# Altium schematic sheet schematic.SchDoc(schematic)
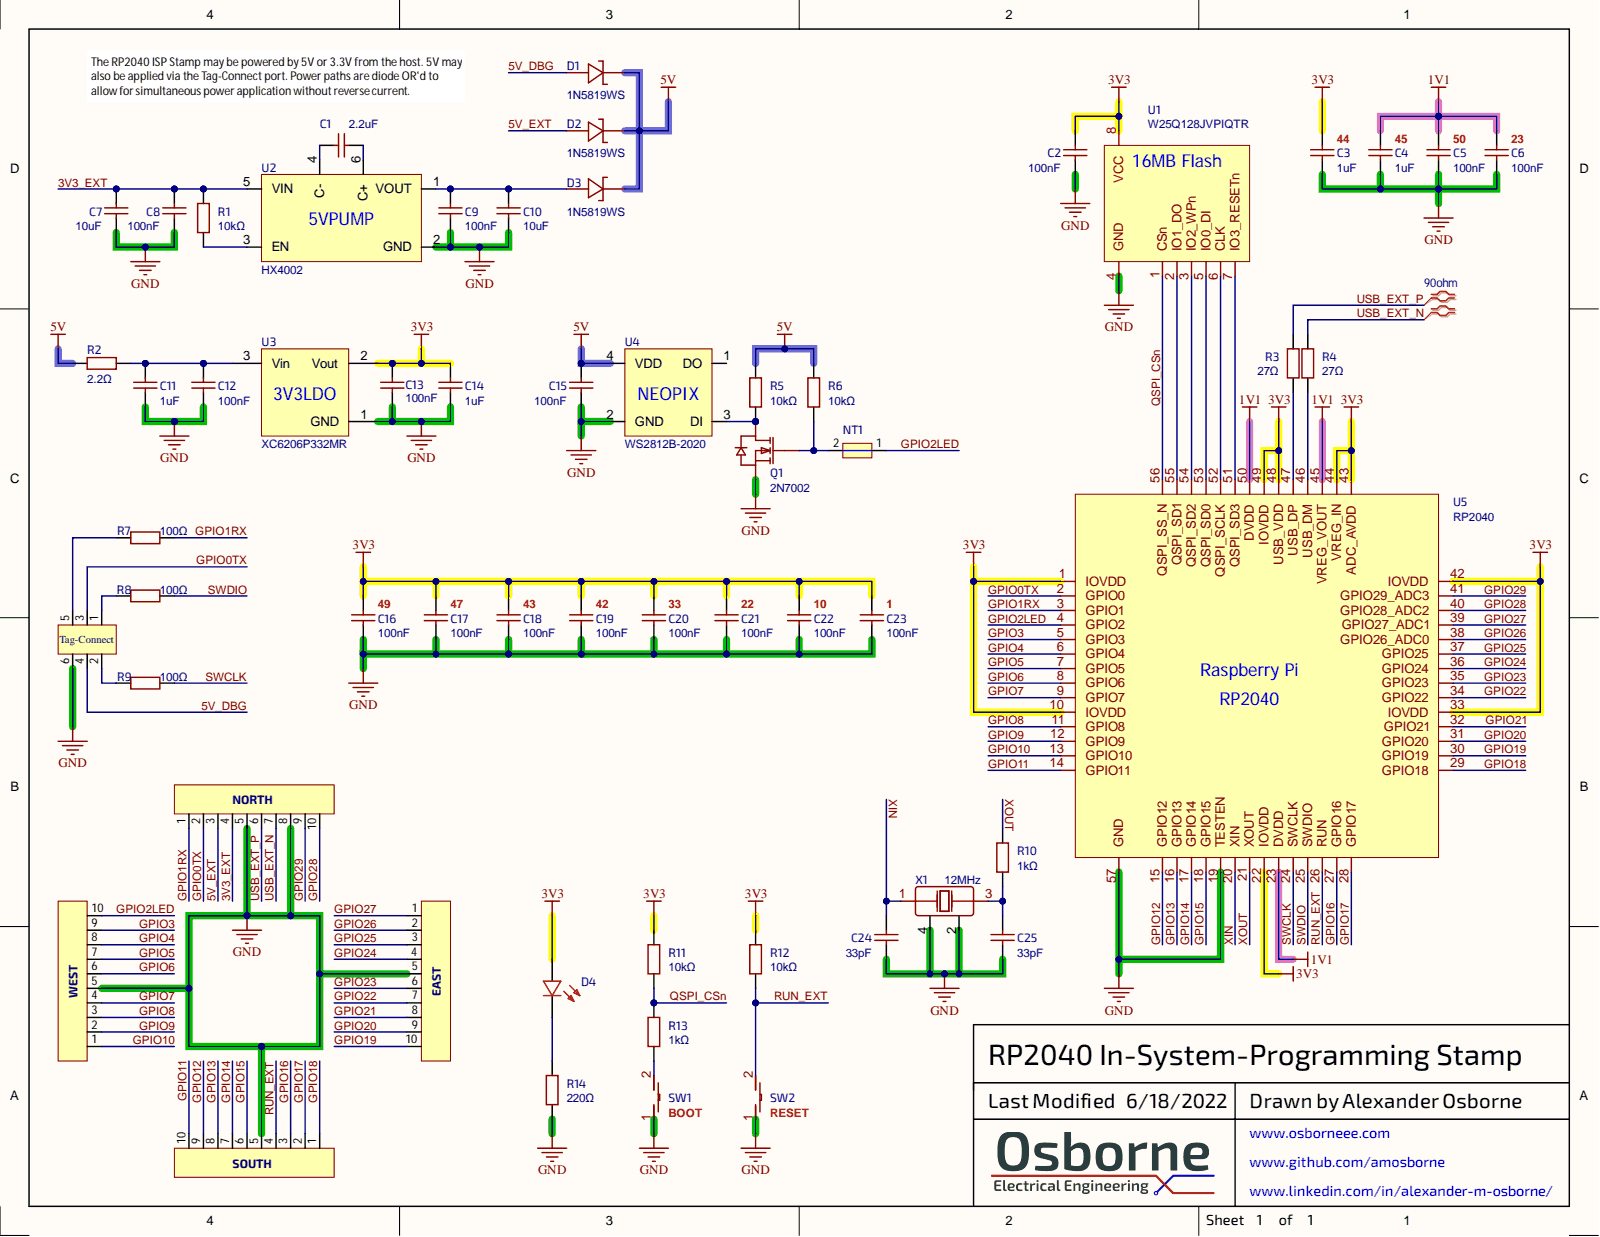
[diagram p0: schematic.SchDoc]

## Parts
C1  2.2uF  [C0603]
C2  100nF  [C0402_NEW]
C3  1uF  [C0402]
C4  1uF  [C0402]
C5  100nF  [C0402_NEW]
C6  100nF  [C0402_NEW]
C7  10uF  [C0603]
C8  100nF  [C0402_NEW]
C9  100nF  [C0402_NEW]
C10  10uF  [C0603]
C11  1uF  [C0402]
C12  100nF  [C0402_NEW]
C13  100nF  [C0402_NEW]
C14  1uF  [C0402]
C15  100nF  [C0402_NEW]
C16  100nF  [C0402_NEW]
C17  100nF  [C0402_NEW]
C18  100nF  [C0402_NEW]
C19  100nF  [C0402_NEW]
C20  100nF  [C0402_NEW]
C21  100nF  [C0402_NEW]
C22  100nF  [C0402_NEW]
C23  100nF  [C0402_NEW]
C24  33pF  [C0402]
C25  33pF  [C0402]
D1  1N5819WS  [SOD-323_L1.8-W1.3-LS2.5-RD]
D2  1N5819WS  [SOD-323_L1.8-W1.3-LS2.5-RD]
D3  1N5819WS  [SOD-323_L1.8-W1.3-LS2.5-RD]
D4  19-217/GHC-YR1S2/3T  [LED0603-R-RD]  (value not drawn)
EAST  STAMPEDGE  (value not drawn)  (no BOM)
J1  TC2030-IDC-NL  (value not drawn)  (no BOM)
NORTH  STAMPEDGE  (value not drawn)  (no BOM)
NT1  NETTIE  (value not drawn)
Q1  2N7002  [SOT-23-3_L2.9-W1.3-P1.90-LS2.4-BR]
R1  10kΩ  [R0402]
R2  2.2Ω  [R0603]
R3  27Ω  [R0603]
R4  27Ω  [R0603]
R5  10kΩ  [R0402]
R6  10kΩ  [R0402]
R7  100Ω  [R0402]
R8  100Ω  [R0402]
R9  100Ω  [R0402]
R10  1kΩ  [R0402]
R11  10kΩ  [R0402]
R12  10kΩ  [R0402]
R13  1kΩ  [R0402]
R14  220Ω  [R0402]
SOUTH  STAMPEDGE  (value not drawn)  (no BOM)
SW1  B3U-1000P  [KEY-SMD_B3U-1000PM]  (value not drawn)
SW2  B3U-1000P  [KEY-SMD_B3U-1000PM]  (value not drawn)
U1  W25Q128JVPIQTR  [WSON-8_L6.0-W5.0-P1.27-BL]
U2  HX4002  [SOT-23-6_L2.9-W1.6-P0.95-LS2.8-BR]
U3  XC6206P332MR  [SOT-23-3_L2.9-W1.6-P1.90-LS2.8-BR]
U4  WS2812B-2020  [LED-SMD_4P-L2.0-W2.0-TL_WS2812B-2020]
U5  RP2040  [LQFN-56_L7.0-W7.0-P0.4-EP]
WEST  STAMPEDGE  (value not drawn)  (no BOM)
X1  12MHz  [OSC-SMD_4P-L3.2-W2.5-BL]

## Nets
1V1: C4.2 C5.2 C6.2 U5.23(DVDD) U5.45(VREG_VOUT) U5.50(DVDD)
3V3: C2.2 C3.2 C13.2 C14.2 C16.2 C17.2 C18.2 C19.2 C20.2 C21.2 C22.2 C23.2 D4.1 R11.2 R12.2 U1.8(VCC) U3.2 U5.1(IOVDD) U5.10(IOVDD) U5.22(IOVDD) U5.33(IOVDD) U5.42(IOVDD) U5.43(ADC_AVDD) U5.44(VREG_IN) U5.48(USB_VDD) U5.49(IOVDD)
5V: C15.2 D1.1 D2.1 D3.1 R2.1 R5.2 R6.2 U4.4(VDD)
GND: C2.1 C3.1 C4.1 C5.1 C6.1 C7.1 C8.1 C9.1 C10.1 C11.1 C12.1 C13.1 C14.1 C15.1 C16.1 C17.1 C18.1 C19.1 C20.1 C21.1 C22.1 C23.1 C24.1 C25.1 EAST.5 J1.6 NORTH.5 NORTH.8 Q1.2 R14.1 SOUTH.5 SW1.1 SW2.1 U1.4(GND) U2.2(GND) U3.1 U4.2(GND) U5.19(TESTEN) U5.57(GND) WEST.5 X1.2 X1.4
3V3_EXT: C7.2 C8.2 NORTH.4 R1.2 U2.5(VIN)
5V_DBG: D1.2 J1.4
5V_EXT: D2.2 NORTH.3
GPIO0TX: J1.3 NORTH.2 U5.2(GPIO0)
GPIO1RX: NORTH.1 R7.1 U5.3(GPIO1)
GPIO2LED: NT1.1 U5.4(GPIO2) WEST.10
GPIO3: U5.5(GPIO3) WEST.9
GPIO4: U5.6(GPIO4) WEST.8
GPIO5: U5.7(GPIO5) WEST.7
GPIO6: U5.8(GPIO6) WEST.6
GPIO7: U5.9(GPIO7) WEST.4
GPIO8: U5.11(GPIO8) WEST.3
GPIO9: U5.12(GPIO9) WEST.2
GPIO10: U5.13(GPIO10) WEST.1
GPIO11: SOUTH.10 U5.14(GPIO11)
GPIO12: SOUTH.9 U5.15(GPIO12)
GPIO13: SOUTH.8 U5.16(GPIO13)
GPIO14: SOUTH.7 U5.17(GPIO14)
GPIO15: SOUTH.6 U5.18(GPIO15)
GPIO16: SOUTH.3 U5.27(GPIO16)
GPIO17: SOUTH.2 U5.28(GPIO17)
GPIO18: SOUTH.1 U5.29(GPIO18)
GPIO19: EAST.10 U5.30(GPIO19)
GPIO20: EAST.9 U5.31(GPIO20)
GPIO21: EAST.8 U5.32(GPIO21)
GPIO22: EAST.7 U5.34(GPIO22)
GPIO23: EAST.6 U5.35(GPIO23)
GPIO24: EAST.4 U5.36(GPIO24)
GPIO25: EAST.3 U5.37(GPIO25)
GPIO26: EAST.2 U5.38(GPIO26_ADC0)
GPIO27: EAST.1 U5.39(GPIO27_ADC1)
GPIO28: NORTH.10 U5.40(GPIO28_ADC2)
GPIO29: NORTH.9 U5.41(GPIO29_ADC3)
QSPI_CSn: R11.1 R13.2 U1.1(CSn) U5.56(QSPI_SS_N)
RUN_EXT: R12.1 SOUTH.4 SW2.2 U5.26(RUN)
SWCLK: R9.1 U5.24(SWCLK)
SWDIO: R8.1 U5.25(SWDIO)
USB_EXT_N: NORTH.7 R4.2
USB_EXT_P: NORTH.6 R3.2
XIN: C24.2 U5.20(XIN) X1.1
XOUT: R10.1 U5.21(XOUT)
NetC1_1: C1.1 U2.6(C+)
NetC1_2: C1.2 U2.4(C-)
NetC9_2: C9.2 C10.2 D3.2 U2.1(VOUT)
NetC11_2: C11.2 C12.2 R2.2 U3.3
NetC25_2: C25.2 R10.2 X1.3
NetD4_2: D4.2 R14.2
NetJ1_1: J1.1 R8.2
NetJ1_2: J1.2 R9.2
NetJ1_5: J1.5 R7.2
NetNT1_2: NT1.2 Q1.1 R6.1
NetQ1_3: Q1.3 R5.1 U4.3(DI)
NetR1_1: R1.1 U2.3(EN)
NetR3_1: R3.1 U5.47(USB_DP)
NetR4_1: R4.1 U5.46(USB_DM)
NetR13_1: R13.1 SW1.2
NetU1_2: U1.2(IO1_DO) U5.55(QSPI_SD1)
NetU1_3: U1.3(IO2_WPn) U5.54(QSPI_SD2)
NetU1_5: U1.5(IO0_DI) U5.53(QSPI_SD0)
NetU1_6: U1.6(CLK) U5.52(QSPI_SCLK)
NetU1_7: U1.7(IO3_RESETn) U5.51(QSPI_SD3)

Not connected: U4 1(DO)

## Library data of the parts
C1 = 2.2uF: 16V 2.2uF X5R ±10% 0603  Multilayer Ceramic Capacitors MLCC - SMD/SMT ROHS; BOM_Manufacturer: SAMSUNG; BOM_Manufacturer Part: CL10A225KO8NNNC; nameAlias: Capacitance; BOM_JLCPCB Part Class: Basic Part; BOM_Supplier: LCSC; BOM_Supplier Part: C23630; Component Kind: Standard; Library Name: sch_library.SchLib; spicePre: C; spiceSymbolName: CL10A225KO8NNNC
C2 C5 C6 C8 C9 C12 C13 C15-C23 = 100nF: 16V 100nF X7R ±10% 0402  Multilayer Ceramic Capacitors MLCC - SMD/SMT ROHS; BOM_Manufacturer: SAMSUNG; BOM_Manufacturer Part: CL05B104KO5NNNC; nameAlias: Capacitance; BOM_JLCPCB Part Class: Basic Part; BOM_Supplier: LCSC; BOM_Supplier Part: C1525; Component Kind: Standard; Library Name: sch_library.SchLib; spicePre: C; spiceSymbolName: CL05B104KO5NNNC
C3 C4 C11 C14 = 1uF: 25V 1uF X5R ±10% 0402  Multilayer Ceramic Capacitors MLCC - SMD/SMT ROHS; BOM_Manufacturer: SAMSUNG; BOM_Manufacturer Part: CL05A105KA5NQNC; nameAlias: Capacitance; BOM_JLCPCB Part Class: Basic Part; BOM_Supplier: LCSC; BOM_Supplier Part: C52923; Component Kind: Standard; Library Name: sch_library.SchLib; spicePre: C; spiceSymbolName: CL05A105KA5NQNC
C7 C10 = 10uF: X5R 25V ±20% 10uF 0603 Multilayer Ceramic Capacitors MLCC - SMD/SMT ROHS; BOM_Manufacturer: SAMSUNG; BOM_Manufacturer Part: CL10A106MA8NRNC; nameAlias: Capacitance; BOM_JLCPCB Part Class: Basic Part; BOM_Supplier: LCSC; BOM_Supplier Part: C96446; Component Kind: Standard; Library Name: sch_library.SchLib; spicePre: C; spiceSymbolName: CL10A106MA8NRNC
C24 C25 = 33pF: 50V 33pF C0G ±5% 0402  Multilayer Ceramic Capacitors MLCC - SMD/SMT ROHS; BOM_Manufacturer: FH; BOM_Manufacturer Part: 0402CG330J500NT; nameAlias: Capacitance; BOM_JLCPCB Part Class: Basic Part; BOM_Supplier: LCSC; BOM_Supplier Part: C1562; Component Kind: Standard; Library Name: sch_library.SchLib; spicePre: C; spiceSymbolName: 0402CG330J500NT
D1-D3 = 1N5819WS: 40V 600mV@1A 1A SOD-323  Schottky Barrier Diodes (SBD) ROHS; BOM_Manufacturer: Guangdong Hottech; Contributor: LCSC; BOM_JLCPCB Part Class: Basic Part; BOM_Manufacturer Part: 1N5819WS; BOM_Supplier: LCSC; BOM_Supplier Part: C191023; Component Kind: Standard; Library Name: sch_library.SchLib; spicePre: D; spiceSymbolName: 1N5819WS
D4 = 19-217/GHC-YR1S2/3T: 20mA 285mcd 3.3V 518nm Colorless transparence -40℃~+85℃ 520nm~535nm Emerald 120° 110mW 0603  Light Emitting Diodes (LED) ROHS; BOM_Manufacturer: EVERLIGHT; BOM_JLCPCB Part Class: Basic Part; BOM_Manufacturer Part: 19-217/GHC-YR1S2/3T; BOM_Supplier: LCSC; BOM_Supplier Part: C72043; Component Kind: Standard; Library Name: sch_library.SchLib; spicePre: L; spiceSymbolName: 19-217/GHC-YR1S2/3T
EAST NORTH SOUTH WEST = STAMPEDGE: RP2040 ISP Stamp Castellations; Library Name: sch_library.SchLib
J1 = TC2030-IDC-NL: Tag-Connect 6-Pin No Legs; Library Name: sch_library.SchLib
Q1 = 2N7002: 60V 115mA 200mW 7.5Ω@10V,500mA 2.5V@250μA N Channel SOT-23(SOT-23-3)  MOSFETs ROHS; BOM_Manufacturer: CJ; BOM_JLCPCB Part Class: Basic Part; BOM_Manufacturer Part: 2N7002; BOM_Supplier: LCSC; BOM_Supplier Part: C8545; Component Kind: Standard; Library Name: sch_library.SchLib; spicePre: Q; spiceSymbolName: 2N7002
R1 R5 R6 R11 R12 = 10kΩ: 1/16W Thick Film Resistors 50V ±1% ±100ppm/℃ -55℃~+155℃ 10kΩ 0402  Chip Resistor - Surface Mount ROHS; BOM_Manufacturer: UniOhm; BOM_Manufacturer Part: 0402WGF1002TCE; nameAlias: Resistance; Resistance (Ohms): 10K; BOM_JLCPCB Part Class: Basic Part; BOM_Supplier: LCSC; BOM_Supplier Part: C25744; Component Kind: Standard; Library Name: sch_library.SchLib; spicePre: R; spiceSymbolName: 0402WGF1002TCE
R2 = 2.2Ω: 1/10W Thick Film Resistors 75V ±1% ±400ppm/℃ -55℃~+155℃ 2.2Ω 0603  Chip Resistor - Surface Mount ROHS; BOM_Manufacturer: UniOhm; BOM_Manufacturer Part: 0603WAF220KT5E; nameAlias: Resistance; Resistance (Ohms): 2.2; BOM_JLCPCB Part Class: Basic Part; BOM_Supplier: LCSC; BOM_Supplier Part: C22939; Component Kind: Standard; Library Name: sch_library.SchLib; spicePre: R; spiceSymbolName: 0603WAF220KT5E
R3 R4 = 27Ω: 1/10W Thick Film Resistors 75V ±1% ±200ppm/℃ -55℃~+155℃ 27Ω 0603  Chip Resistor - Surface Mount ROHS; BOM_Manufacturer: UniOhm; BOM_Manufacturer Part: 0603WAF270JT5E; nameAlias: Resistance; Resistance (Ohms): 27; BOM_JLCPCB Part Class: Basic Part; BOM_Supplier: LCSC; BOM_Supplier Part: C25190; Component Kind: Standard; Library Name: sch_library.SchLib; spicePre: R; spiceSymbolName: 0603WAF270JT5E
R7-R9 = 100Ω: 1/16W Thick Film Resistors 50V ±1% ±200ppm/℃ -55℃~+155℃ 100Ω 0402  Chip Resistor - Surface Mount ROHS; BOM_Manufacturer: UniOhm; BOM_Manufacturer Part: 0402WGF1000TCE; nameAlias: Resistance; Resistance (Ohms): 100; BOM_JLCPCB Part Class: Basic Part; BOM_Supplier: LCSC; BOM_Supplier Part: C25076; Component Kind: Standard; Library Name: sch_library.SchLib; spicePre: R; spiceSymbolName: 0402WGF1000TCE
R10 R13 = 1kΩ: 1/16W Thick Film Resistors 50V ±1% ±100ppm/℃ -55℃~+155℃ 1kΩ 0402  Chip Resistor - Surface Mount ROHS; BOM_Manufacturer: UniOhm; BOM_Manufacturer Part: 0402WGF1001TCE; nameAlias: Resistance; Resistance (Ohms): 1K; BOM_JLCPCB Part Class: Basic Part; BOM_Supplier: LCSC; BOM_Supplier Part: C11702; Component Kind: Standard; Library Name: sch_library.SchLib; spicePre: R; spiceSymbolName: 0402WGF1001TCE
R14 = 220Ω: 1/16W Thick Film Resistors 50V ±1% ±100ppm/℃ -55℃~+155℃ 220Ω 0402  Chip Resistor - Surface Mount ROHS; BOM_Manufacturer: UniOhm; BOM_Manufacturer Part: 0402WGF2200TCE; nameAlias: Resistance; Resistance (Ohms): 220; BOM_JLCPCB Part Class: Basic Part; BOM_Supplier: LCSC; BOM_Supplier Part: C25091; Component Kind: Standard; Library Name: sch_library.SchLib; spicePre: R; spiceSymbolName: 0402WGF2200TCE
SW1 SW2 = B3U-1000P: 2.5mm 1.6mm Round Button 50mA Brick nogging 3mm SPST 12V SMD,3x2.5x1.6mm  Tactile Switches ROHS; BOM_Manufacturer: Omron Electronics; Contributor: LCSC; BOM_JLCPCB Part Class: Extended Part; BOM_Manufacturer Part: B3U-1000P; BOM_Supplier: LCSC; BOM_Supplier Part: C231329; Component Kind: Standard; Library Name: sch_library.SchLib; spicePre: S; spiceSymbolName: B3U-1000P
U1 = W25Q128JVPIQTR: WSON-8_5x6mm  NOR FLASH ROHS; BOM_Manufacturer: Winbond Elec; Contributor: LCSC; BOM_JLCPCB Part Class: Extended Part; BOM_Manufacturer Part: W25Q128JVPIQTR; BOM_Supplier: LCSC; BOM_Supplier Part: C190862; Component Kind: Standard; Library Name: sch_library.SchLib; spicePre: U; spiceSymbolName: W25Q128JVPIQTR
U2 = HX4002: NO Charge pump 1 5V 2.7V~5.5V 250mA 1.2MHz SOT-23-6  DC-DC Converters ROHS; BOM_Manufacturer: HEXIN; Contributor: LCSC; BOM_JLCPCB Part Class: Extended Part; BOM_Manufacturer Part: HX4002; BOM_Supplier: LCSC; BOM_Supplier Part: C172354; Component Kind: Standard; Library Name: sch_library.SchLib; spicePre: U; spiceSymbolName: HX4002
U3 = XC6206P332MR: 200mA 680mV@(100mA) Fixed 3.3V~3.3V Positive 1 6V SOT-23-3L  Linear Voltage Regulators (LDO) ROHS; BOM_Manufacturer: TOREX; BOM_JLCPCB Part Class: Basic Part; BOM_Manufacturer Part: XC6206P332MR; BOM_Supplier: LCSC; BOM_Supplier Part: C5446; Component Kind: Standard; Library Name: sch_library.SchLib; spicePre: U; spiceSymbolName: XC6206P332MR
U4 = WS2812B-2020: 5mA 5.3V -25℃~+85℃ RGB SMD,2x2mm  Light Emitting Diodes (LED) ROHS; BOM_Manufacturer: Worldsemi; Contributor: LCSC; BOM_JLCPCB Part Class: Extended Part; BOM_Manufacturer Part: WS2812B-2020; BOM_Supplier: LCSC; BOM_Supplier Part: C965555; Component Kind: Standard; Library Name: sch_library.SchLib; spicePre: L; spiceSymbolName: WS2812B-2020
U5 = RP2040: ARM Cortex-M0 1@x4ch/12bit USB Host/Device 2 264KB 2 133MHz 30 2 QFN-56(7x7)  Microcontroller Units (MCUs/MPUs/SOCs) ROHS; BOM_Manufacturer: Raspberry Pi(树莓派); Contributor: LCSC; BOM_JLCPCB Part Class: Extended Part; BOM_Manufacturer Part: RP2040; BOM_Supplier: LCSC; BOM_Supplier Part: C2040; Component Kind: Standard; Library Name: sch_library.SchLib; spicePre: U; spiceSymbolName: RP2040
X1 = 12MHz: 12MHz SMD Crystal Resonator 20pF ±10ppm ±30ppm -40℃~+85℃ SMD3225-4P  Crystals ROHS; BOM_Manufacturer: YXC; BOM_Manufacturer Part: X322512MSB4SI; nameAlias: Frequency; BOM_JLCPCB Part Class: Basic Part; BOM_Supplier: LCSC; BOM_Supplier Part: C9002; Component Kind: Standard; Library Name: sch_library.SchLib; spicePre: X; spiceSymbolName: X322512MSB4SI
NT1 = NETTIE: Component Kind: Net Tie; Library Name: sch_library.SchLib
